# Altium schematic sheet MCU_POWER.SchDoc
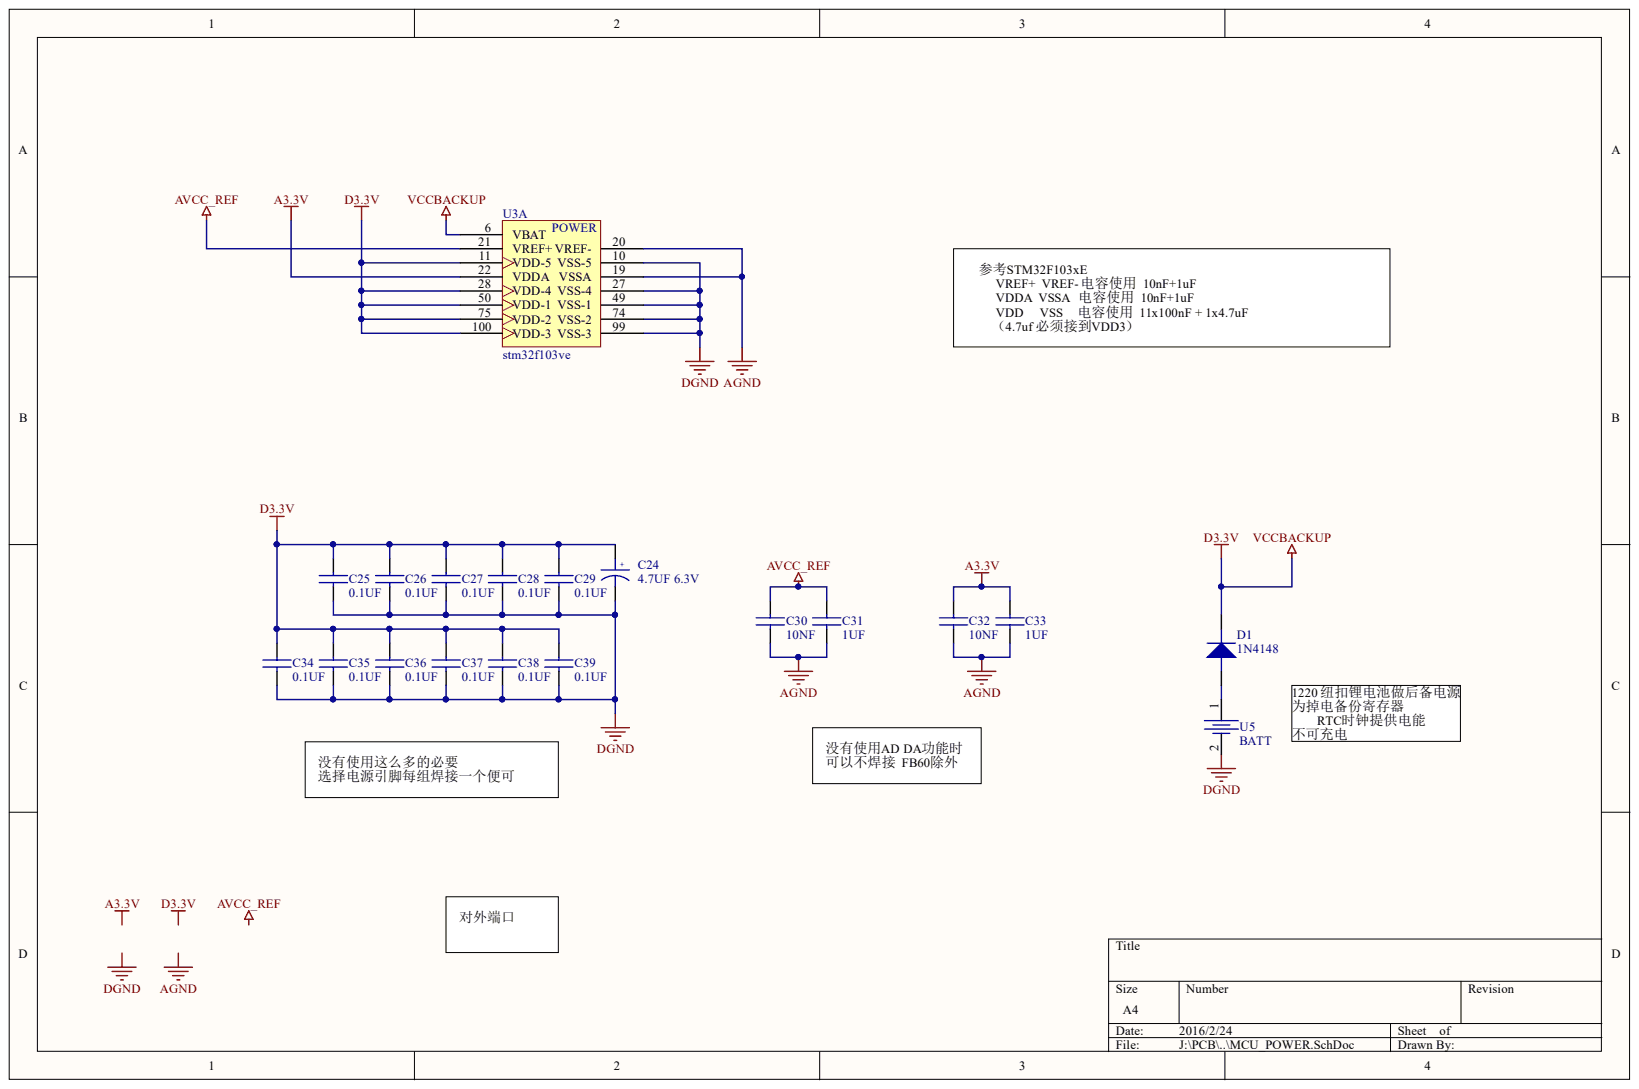
[diagram p0: MCU_POWER.SchDoc]

## Parts
C24  4.7UF 6.3V  [CAPMP3216X180]
C25  0.1UF  [CAPC1005X05N]
C26  0.1UF  [CAPC1005X05N]
C27  0.1UF  [CAPC1005X05N]
C28  0.1UF  [CAPC1005X05N]
C29  0.1UF  [CAPC1005X05N]
C30  10NF  [CAPC1005X05N]
C31  1UF  [CAPC1005X05N]
C32  10NF  [CAPC1005X05N]
C33  1UF  [CAPC1005X05N]
C34  0.1UF  [CAPC1005X05N]
C35  0.1UF  [CAPC1005X05N]
C36  0.1UF  [CAPC1005X05N]
C37  0.1UF  [CAPC1005X05N]
C38  0.1UF  [CAPC1005X05N]
C39  0.1UF  [CAPC1005X05N]
D1  1N4148  [SOD123_370X125-2N]
U3  stm32f103ve  [LQFP100-M]  (part A)
U5  BATT  [VBAT_PWD]

## Nets
A3.3V: C32.1 C33.1 U3.22(VDDA)
AGND: C30.2 C31.2 C32.2 C33.2 U3.19(VSSA) U3.20(VREF-)
AVCC_REF: C30.1 C31.1 U3.21(VREF+)
D3.3V: C24.1 C25.1 C26.1 C27.1 C28.1 C29.1 C34.1 C35.1 C36.1 C37.1 C38.1 C39.1 D1.K U3.6(VBAT) U3.11(VDD-5) U3.28(VDD-4) U3.50(VDD-1) U3.75(VDD-2) U3.100(VDD-3)
DGND: C24.2 C25.2 C26.2 C27.2 C28.2 C29.2 C34.2 C35.2 C36.2 C37.2 C38.2 C39.2 U3.10(VSS-5) U3.27(VSS-4) U3.49(VSS-1) U3.74(VSS-2) U3.99(VSS-3) U5.2
NetD1_A: D1.A U5.1

## Library data of the parts
C24 = CAPACITOR POL: Capacitor polarity; Component Kind: Standard; Library Name: RCL_Standard.SchLib
C25-C39 = CAP: Capacitor nonpolarity; Component Kind: Standard; Library Name: RCL_Standard.SchLib
D1 = DIODE GENERAL: Component Kind: Standard; Description: Diode General; Library Name: RCL_Standard.SchLib
U3 = STM32F103V: MCU; Component Kind: Standard; Library Name: IC.SchLib
U5 = BATT: CR1220锂电池，不可充电; Component Kind: Standard; Library Name: Other.SchLib
